# Altium schematic sheet p1_bt_sorry.SchDoc(p1_bt_sorry)
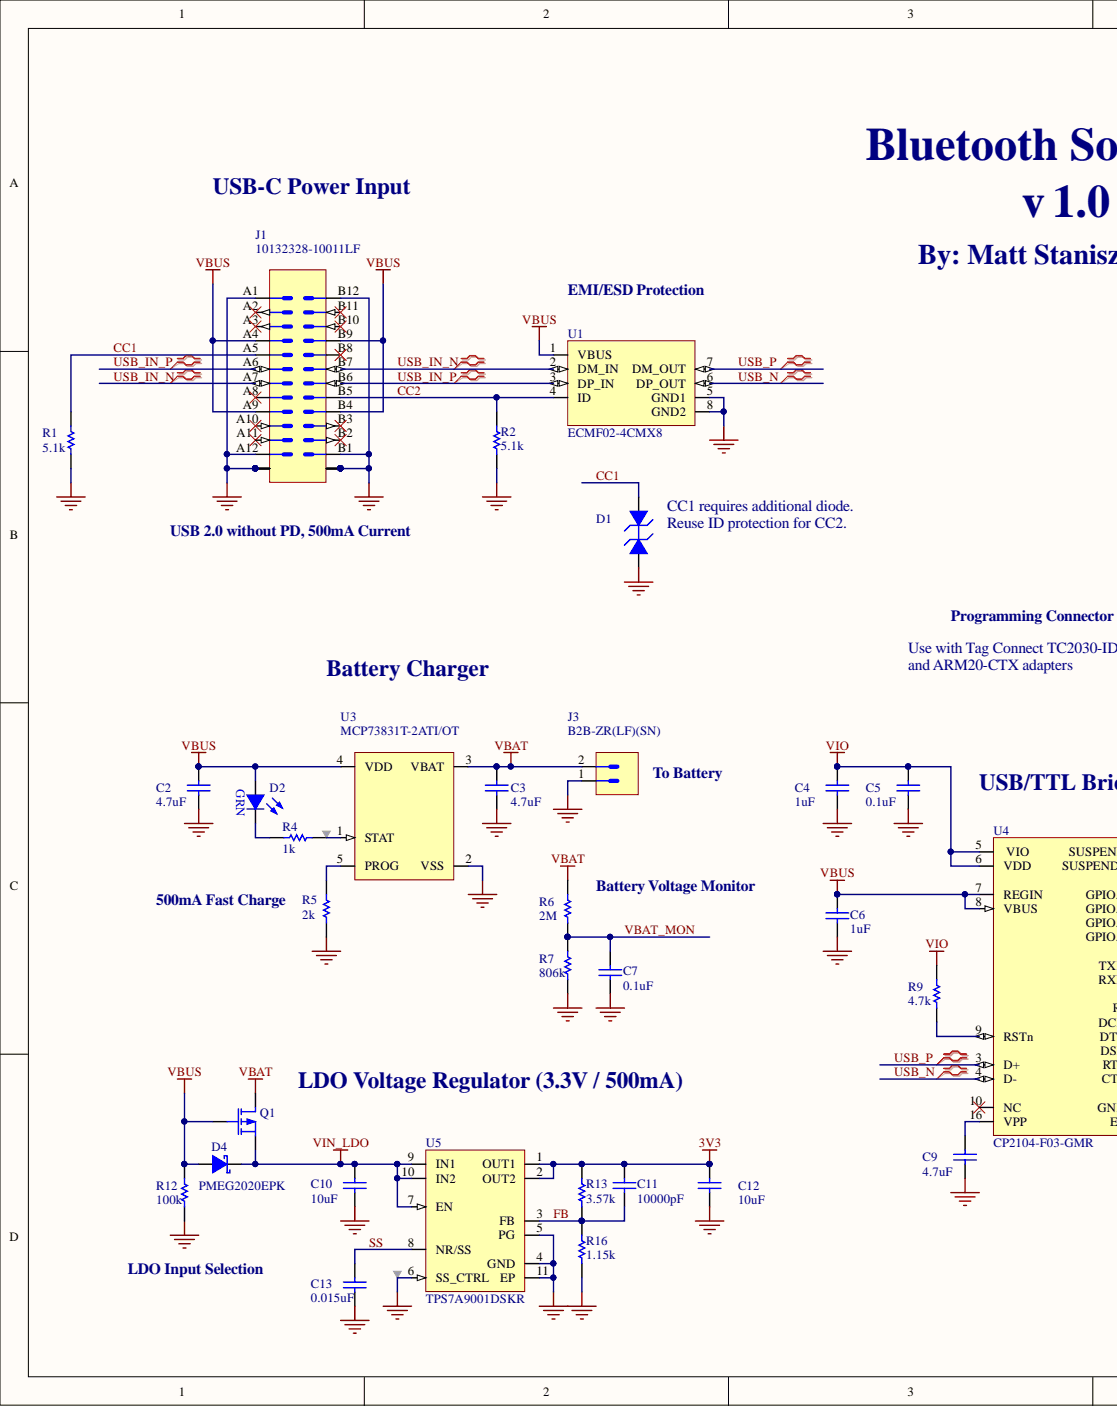
[diagram p0: p1_bt_sorry.SchDoc, left]
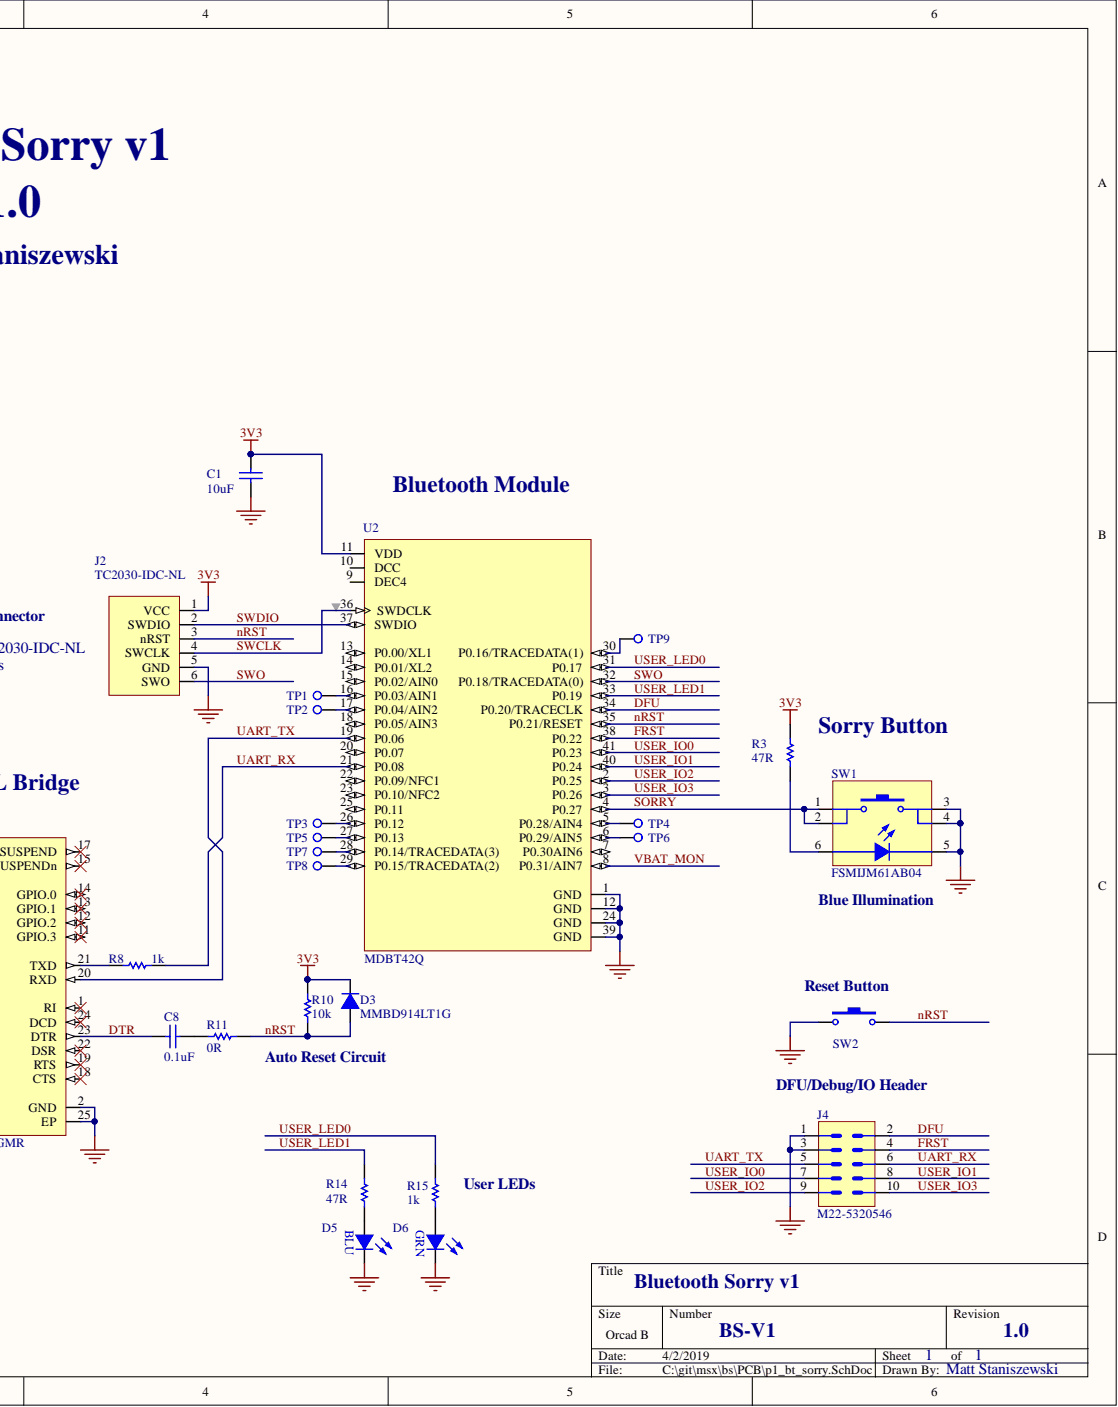
[diagram p1: p1_bt_sorry.SchDoc, right]

## Parts
C1  10uF  [C0402]
C2  4.7uF  [C0402]
C3  4.7uF  [C0402]
C4  1uF  [C0402]
C5  0.1uF  [C0402]
C6  1uF  [C0402]
C7  0.1uF  [C0402]
C8  0.1uF  [C0402]
C9  4.7uF  [C0402]
C10  10uF  [C0402]
C11  10000pF  [C0402]
C12  10uF  [C0402]
C13  0.015uF  [C0402]
D1  ESDAXLC6-1BU2  [ONS_0201]  (value not drawn)
D2  5988070107F  [LED0603]  (value not drawn)
D3  MMBD914LT1G  [ONS_SOT23]
D4  PMEG2020EPK  [NXP_DFN1608D-2]
D5  5988091107F  [LED0603]  (value not drawn)
D6  5988070107F  [LED0603]  (value not drawn)
J1  10132328-10011LF  [AMP_USBC_VT]
J2  TC2030-IDC-NL  [TAG_6P]
J3  B2B-ZR(LF)(SN)  [JST_ZH_2P_PTH_VT]
J4  M22-5320546  [HDR10_2X5_2MM]
Q1  DMP21D0UFB4-7B  [DIO_X2-DFN1006-3]  (value not drawn)
R1  5.1k  [R0402]
R2  5.1k  [R0402]
R3  47R  [R0402]
R4  1k  [R0402]
R5  2k  [R0402]
R6  2M  [R0402]
R7  806k  [R0402]
R8  1k  [R0402]
R9  4.7k  [R0402]
R10  10k  [R0402]
R11  0R  [R0402]
R12  100k  [R0402]
R13  3.57k  [R0402]
R14  47R  [R0402]
R15  1k  [R0402]
R16  1.15k  [R0402]
SW1  FSMIJM61AB04  [TE_FSMIJM61AB04]
SW2  PTS645SM43SMTR92LFS  [CK_PTS645]  (value not drawn)
TP1  TP SMT 1MM  [TP_SMT_1MM]  (value not drawn)
TP2  TP SMT 1MM  [TP_SMT_1MM]  (value not drawn)
TP3  TP SMT 1MM  [TP_SMT_1MM]  (value not drawn)
TP4  TP SMT 1MM  [TP_SMT_1MM]  (value not drawn)
TP5  TP SMT 1MM  [TP_SMT_1MM]  (value not drawn)
TP6  TP SMT 1MM  [TP_SMT_1MM]  (value not drawn)
TP7  TP SMT 1MM  [TP_SMT_1MM]  (value not drawn)
TP8  TP SMT 1MM  [TP_SMT_1MM]  (value not drawn)
TP9  TP SMT 1MM  [TP_SMT_1MM]  (value not drawn)
U1  ECMF02-4CMX8  [STM_UQFN-8L]
U2  MDBT42Q  [RAY_MDBT42Q]
U3  MCP73831T-2ATI/OT  [MCP_SOT23-5]
U4  CP2104-F03-GMR  [SIL_QFN-24]
U5  TPS7A9001DSKR  [TI_DSK]

## Nets
3V3: C1.2 C11.2 C12.2 D3.3 J2.1(VCC) R3.2 R10.2 R13.2 U2.11(VDD) U5.1(OUT1) U5.2(OUT2)
GND: C1.1 C2.1 C3.1 C4.1 C5.1 C6.1 C7.1 C9.1 C10.1 C12.1 C13.1 D1.1 D5.1 D6.1 J1.A1 J1.A12 J1.B1 J1.B12 J1.SHA1 J1.SHA2 J1.SHA3 J1.SHA4 J1.SHA5 J1.SHA6 J1.SHA7 J1.SHB1 J1.SHB2 J1.SHB3 J1.SHB4 J1.SHB5 J1.SHB6 J1.SHB7 J2.5(GND) J3.1 J4.1 J4.3 R1.1 R2.1 R5.1 R7.1 R12.1 R16.1 SW1.3 SW1.4 SW1.5 SW2.1 U1.5(GND1) U1.8(GND2) U2.1(GND) U2.12(GND) U2.24(GND) U2.39(GND) U3.2(VSS) U4.2(GND) U4.25(EP) U5.4(GND) U5.5(PG) U5.6(SS_CTRL) U5.11(EP)
VBAT: C3.2 J3.2 Q1.3 R6.2 U3.3(VBAT)
VBUS: C2.2 C6.2 D2.2 D4.2 J1.A4 J1.A9 J1.B4 J1.B9 Q1.1 R12.2 U1.1(VBUS) U3.4(VDD) U4.7(REGIN) U4.8(VBUS)
VIN_LDO: C10.2 D4.1 Q1.2 U5.7(EN) U5.9(IN1) U5.10(IN2)
VIO: C4.2 C5.2 R9.2 U4.5(VIO) U4.6(VDD)
CC1: D1.2 J1.A5 R1.2
CC2: J1.B5 R2.2 U1.4(ID)
DFU: J4.2 U2.34(P0.20/TRACECLK)
DTR: C8.2 U4.23(DTR)
FB: C11.1 R13.1 R16.2 U5.3(FB)
FRST: J4.4 U2.38(P0.22)
nRST: D3.1 J2.3(nRST) R10.1 R11.2 SW2.2 U2.35(P0.21/RESET)
SORRY: SW1.1 SW1.2 U2.4(P0.27)
SS: C13.2 U5.8(NR/SS)
SWCLK: J2.4(SWCLK) U2.36(SWDCLK)
SWDIO: J2.2(SWDIO) U2.37(SWDIO)
SWO: J2.6(SWO) U2.32(P0.18/TRACEDATA(0))
UART_RX: J4.6 R8.1 U2.21(P0.08)
UART_TX: J4.5 U2.19(P0.06) U4.20(RXD)
USB_IN_N: J1.A7 J1.B7 U1.2(DM_IN)
USB_IN_P: J1.A6 J1.B6 U1.3(DP_IN)
USB_N: U1.6(DP_OUT) U4.4(D-)
USB_P: U1.7(DM_OUT) U4.3(D+)
USER_IO0: J4.7 U2.41(P0.23)
USER_IO1: J4.8 U2.40(P0.24)
USER_IO2: J4.9 U2.2(P0.25)
USER_IO3: J4.10 U2.3(P0.26)
USER_LED0: R15.2 U2.31(P0.17)
USER_LED1: R14.2 U2.33(P0.19)
VBAT_MON: C7.2 R6.1 R7.2 U2.8(P0.31/AIN7)
NetC8_1: C8.1 R11.1
NetC9_2: C9.2 U4.16(VPP)
NetD2_1: D2.1 R4.1
NetD5_2: D5.2 R14.1
NetD6_2: D6.2 R15.1
NetR3_1: R3.1 SW1.6
NetR4_2: R4.2 U3.1(STAT)
NetR5_2: R5.2 U3.5(PROG)
NetR8_2: R8.2 U4.21(TXD)
NetR9_1: R9.1 U4.9(RSTn)
NetTP1_1: TP1.1 U2.16(P0.03/AIN1)
NetTP2_1: TP2.1 U2.17(P0.04/AIN2)
NetTP3_1: TP3.1 U2.26(P0.12)
NetTP4_1: TP4.1 U2.5(P0.28/AIN4)
NetTP5_1: TP5.1 U2.27(P0.13)
NetTP6_1: TP6.1 U2.6(P0.29/AIN5)
NetTP7_1: TP7.1 U2.28(P0.14/TRACEDATA(3))
NetTP8_1: TP8.1 U2.29(P0.15/TRACEDATA(2))
NetTP9_1: TP9.1 U2.30(P0.16/TRACEDATA(1))

Not connected: J1 A2 A3 A8 A10 A11 B2 B3 B8 B10 B11; U2 7(P0.30AIN6) 9(DEC4) 10(DCC) 13(P0.00/XL1) 14(P0.01/XL2) 15(P0.02/AIN0) 18(P0.05/AIN3) 20(P0.07) 22(P0.09/NFC1) 23(P0.10/NFC2) 25(P0.11); U4 1(RI) 10(NC) 11(GPIO.3) 12(GPIO.2) 13(GPIO.1) 14(GPIO.0) 15(SUSPENDn) 17(SUSPEND) 18(CTS) 19(RTS) 22(DSR) 24(DCD)
